# Altium schematic sheet 74HC14.SchDoc
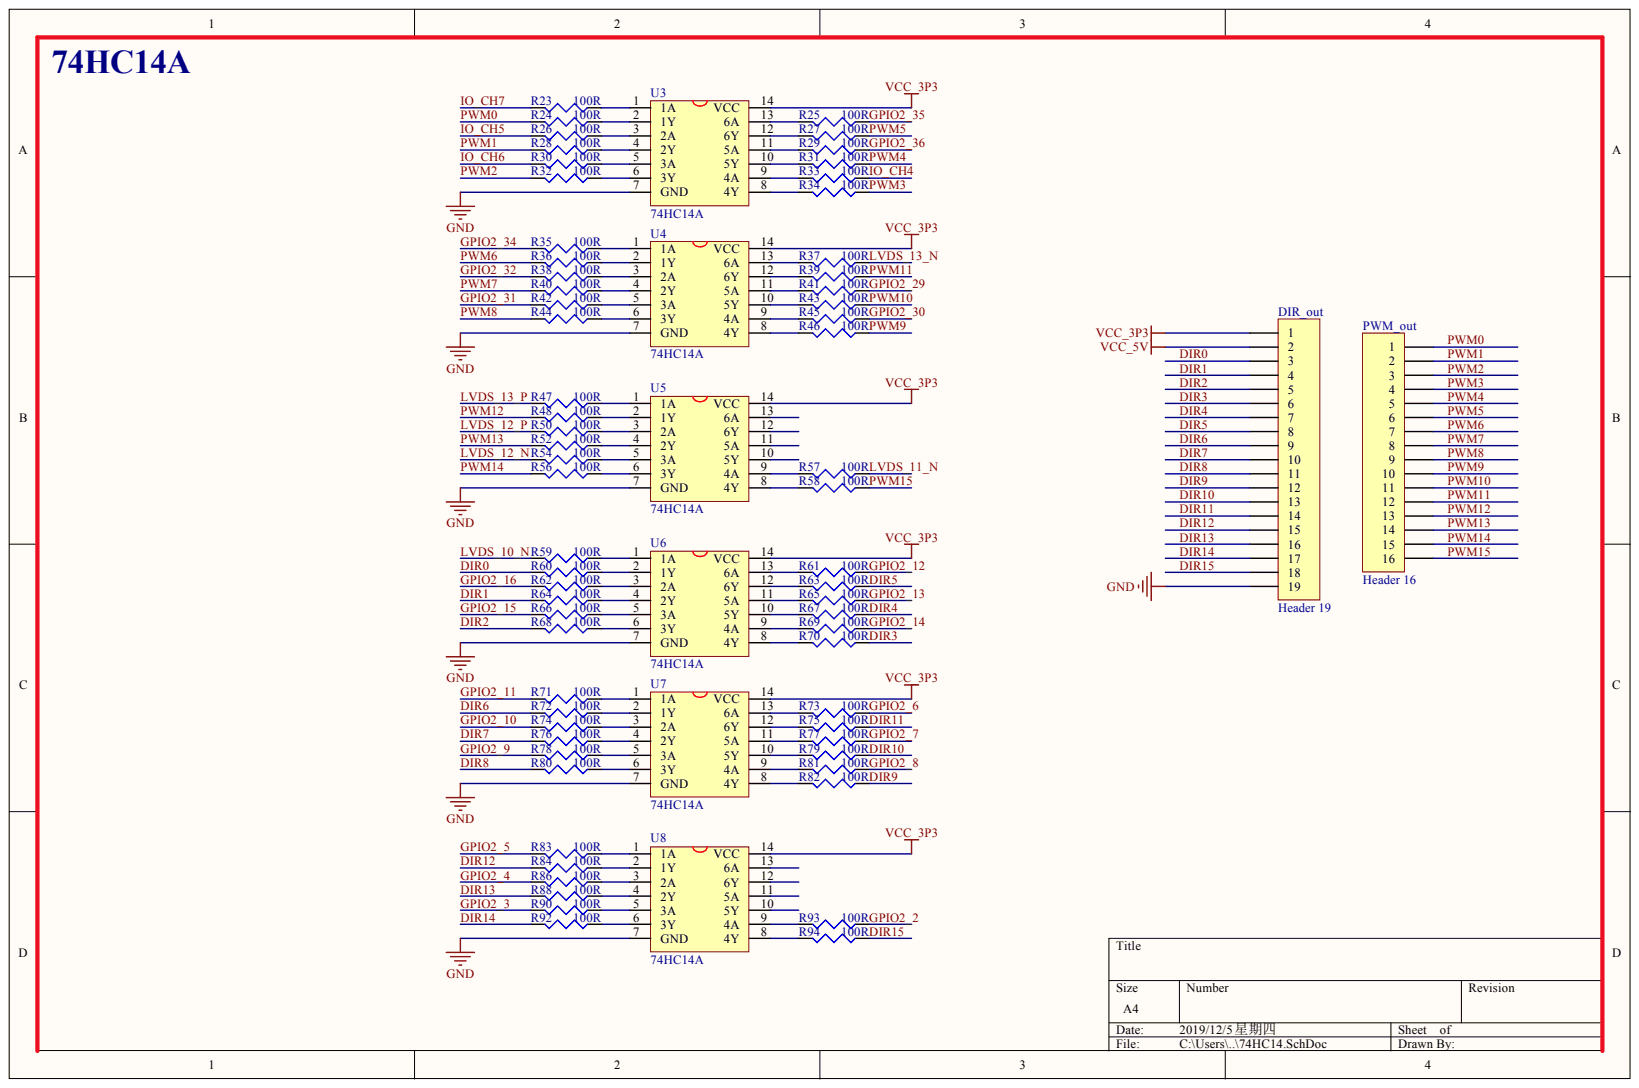
[diagram p0: 74HC14.SchDoc]

## Parts
DIR_out  Header 19  [HDR1X19]
PWM_out  Header 16  [HDR1X16]
R23  100R  [0805]
R24  100R  [0805]
R25  100R  [0805]
R26  100R  [0805]
R27  100R  [0805]
R28  100R  [0805]
R29  100R  [0805]
R30  100R  [0805]
R31  100R  [0805]
R32  100R  [0805]
R33  100R  [0805]
R34  100R  [0805]
R35  100R  [0805]
R36  100R  [0805]
R37  100R  [0805]
R38  100R  [0805]
R39  100R  [0805]
R40  100R  [0805]
R41  100R  [0805]
R42  100R  [0805]
R43  100R  [0805]
R44  100R  [0805]
R45  100R  [0805]
R46  100R  [0805]
R47  100R  [0805]
R48  100R  [0805]
R50  100R  [0805]
R52  100R  [0805]
R54  100R  [0805]
R56  100R  [0805]
R57  100R  [0805]
R58  100R  [0805]
R59  100R  [0805]
R60  100R  [0805]
R61  100R  [0805]
R62  100R  [0805]
R63  100R  [0805]
R64  100R  [0805]
R65  100R  [0805]
R66  100R  [0805]
R67  100R  [0805]
R68  100R  [0805]
R69  100R  [0805]
R70  100R  [0805]
R71  100R  [0805]
R72  100R  [0805]
R73  100R  [0805]
R74  100R  [0805]
R75  100R  [0805]
R76  100R  [0805]
R77  100R  [0805]
R78  100R  [0805]
R79  100R  [0805]
R80  100R  [0805]
R81  100R  [0805]
R82  100R  [0805]
R83  100R  [0805]
R84  100R  [0805]
R86  100R  [0805]
R88  100R  [0805]
R90  100R  [0805]
R92  100R  [0805]
R93  100R  [0805]
R94  100R  [0805]
U3  74HC14A  [74HC14A]
U4  74HC14A  [74HC14A]
U5  74HC14A  [74HC14A]
U6  74HC14A  [74HC14A]
U7  74HC14A  [74HC14A]
U8  74HC14A  [74HC14A]

## Nets
GND: DIR_out.19 U3.7(GND) U4.7(GND) U5.7(GND) U6.7(GND) U7.7(GND) U8.7(GND)
VCC_3P3: DIR_out.1 U3.14(VCC) U4.14(VCC) U5.14(VCC) U6.14(VCC) U7.14(VCC) U8.14(VCC)
VCC_5V: DIR_out.2
DIR0: DIR_out.3 R60.2
DIR1: DIR_out.4 R64.2
DIR2: DIR_out.5 R68.2
DIR3: DIR_out.6 R70.1
DIR4: DIR_out.7 R67.1
DIR5: DIR_out.8 R63.1
DIR6: DIR_out.9 R72.2
DIR7: DIR_out.10 R76.2
DIR8: DIR_out.11 R80.2
DIR9: DIR_out.12 R82.1
DIR10: DIR_out.13 R79.1
DIR11: DIR_out.14 R75.1
DIR12: DIR_out.15 R84.2
DIR13: DIR_out.16 R88.2
DIR14: DIR_out.17 R92.2
DIR15: DIR_out.18 R94.1
GPIO2_2: R93.1
GPIO2_3: R90.2
GPIO2_4: R86.2
GPIO2_5: R83.2
GPIO2_6: R73.1
GPIO2_7: R77.1
GPIO2_8: R81.1
GPIO2_9: R78.2
GPIO2_10: R74.2
GPIO2_11: R71.2
GPIO2_12: R61.1
GPIO2_13: R65.1
GPIO2_14: R69.1
GPIO2_15: R66.2
GPIO2_16: R62.2
GPIO2_29: R41.1
GPIO2_30: R45.1
GPIO2_31: R42.2
GPIO2_32: R38.2
GPIO2_34: R35.2
GPIO2_35: R25.1
GPIO2_36: R29.1
IO_CH4: R33.1
IO_CH5: R26.2
IO_CH6: R30.2
IO_CH7: R23.2
LVDS_10_N: R59.2
LVDS_11_N: R57.1
LVDS_12_N: R54.2
LVDS_12_P: R50.2
LVDS_13_N: R37.1
LVDS_13_P: R47.2
PWM0: PWM_out.1 R24.2
PWM1: PWM_out.2 R28.2
PWM2: PWM_out.3 R32.2
PWM3: PWM_out.4 R34.1
PWM4: PWM_out.5 R31.1
PWM5: PWM_out.6 R27.1
PWM6: PWM_out.7 R36.2
PWM7: PWM_out.8 R40.2
PWM8: PWM_out.9 R44.2
PWM9: PWM_out.10 R46.1
PWM10: PWM_out.11 R43.1
PWM11: PWM_out.12 R39.1
PWM12: PWM_out.13 R48.2
PWM13: PWM_out.14 R52.2
PWM14: PWM_out.15 R56.2
PWM15: PWM_out.16 R58.1
NetR23_1: R23.1 U3.1(1A)
NetR24_1: R24.1 U3.2(1Y)
NetR25_2: R25.2 U3.13(6A)
NetR26_1: R26.1 U3.3(2A)
NetR27_2: R27.2 U3.12(6Y)
NetR28_1: R28.1 U3.4(2Y)
NetR29_2: R29.2 U3.11(5A)
NetR30_1: R30.1 U3.5(3A)
NetR31_2: R31.2 U3.10(5Y)
NetR32_1: R32.1 U3.6(3Y)
NetR33_2: R33.2 U3.9(4A)
NetR34_2: R34.2 U3.8(4Y)
NetR35_1: R35.1 U4.1(1A)
NetR36_1: R36.1 U4.2(1Y)
NetR37_2: R37.2 U4.13(6A)
NetR38_1: R38.1 U4.3(2A)
NetR39_2: R39.2 U4.12(6Y)
NetR40_1: R40.1 U4.4(2Y)
NetR41_2: R41.2 U4.11(5A)
NetR42_1: R42.1 U4.5(3A)
NetR43_2: R43.2 U4.10(5Y)
NetR44_1: R44.1 U4.6(3Y)
NetR45_2: R45.2 U4.9(4A)
NetR46_2: R46.2 U4.8(4Y)
NetR47_1: R47.1 U5.1(1A)
NetR48_1: R48.1 U5.2(1Y)
NetR50_1: R50.1 U5.3(2A)
NetR52_1: R52.1 U5.4(2Y)
NetR54_1: R54.1 U5.5(3A)
NetR56_1: R56.1 U5.6(3Y)
NetR57_2: R57.2 U5.9(4A)
NetR58_2: R58.2 U5.8(4Y)
NetR59_1: R59.1 U6.1(1A)
NetR60_1: R60.1 U6.2(1Y)
NetR61_2: R61.2 U6.13(6A)
NetR62_1: R62.1 U6.3(2A)
NetR63_2: R63.2 U6.12(6Y)
NetR64_1: R64.1 U6.4(2Y)
NetR65_2: R65.2 U6.11(5A)
NetR66_1: R66.1 U6.5(3A)
NetR67_2: R67.2 U6.10(5Y)
NetR68_1: R68.1 U6.6(3Y)
NetR69_2: R69.2 U6.9(4A)
NetR70_2: R70.2 U6.8(4Y)
NetR71_1: R71.1 U7.1(1A)
NetR72_1: R72.1 U7.2(1Y)
NetR73_2: R73.2 U7.13(6A)
NetR74_1: R74.1 U7.3(2A)
NetR75_2: R75.2 U7.12(6Y)
NetR76_1: R76.1 U7.4(2Y)
NetR77_2: R77.2 U7.11(5A)
NetR78_1: R78.1 U7.5(3A)
NetR79_2: R79.2 U7.10(5Y)
NetR80_1: R80.1 U7.6(3Y)
NetR81_2: R81.2 U7.9(4A)
NetR82_2: R82.2 U7.8(4Y)
NetR83_1: R83.1 U8.1(1A)
NetR84_1: R84.1 U8.2(1Y)
NetR86_1: R86.1 U8.3(2A)
NetR88_1: R88.1 U8.4(2Y)
NetR90_1: R90.1 U8.5(3A)
NetR92_1: R92.1 U8.6(3Y)
NetR93_2: R93.2 U8.9(4A)
NetR94_2: R94.2 U8.8(4Y)

Not connected: U5 10(5Y) 11(5A) 12(6Y) 13(6A); U8 10(5Y) 11(5A) 12(6Y) 13(6A)

## Library data of the parts
DIR_out = Header 19: Header, 19-Pin; Component Kind: Standard; LatestRevisionDate: 17-Jul-2002; LatestRevisionNote: Re-released for DXP Platform.; Library Name: Miscellaneous Connectors.IntLib; Signal Integrity: Connector; Publisher: Altium Limited
PWM_out = Header 16: Header, 16-Pin; Component Kind: Standard; LatestRevisionDate: 17-Jul-2002; LatestRevisionNote: Re-released for DXP Platform.; Library Name: Miscellaneous Connectors.IntLib; Signal Integrity: Connector; Publisher: Altium Limited
R23-R48 R50 R52 R54 R56-R84 R86 R88 R90 R92-R94 = R: Resistor; Component Kind: Standard; Library Name: plm60x_v001.SchLib
U3-U8 = 74HC14A: Component Kind: Standard; Library Name: MySchLib.SchLib
